# Altium schematic sheet BT WiFi Power Reset Control.SchDoc
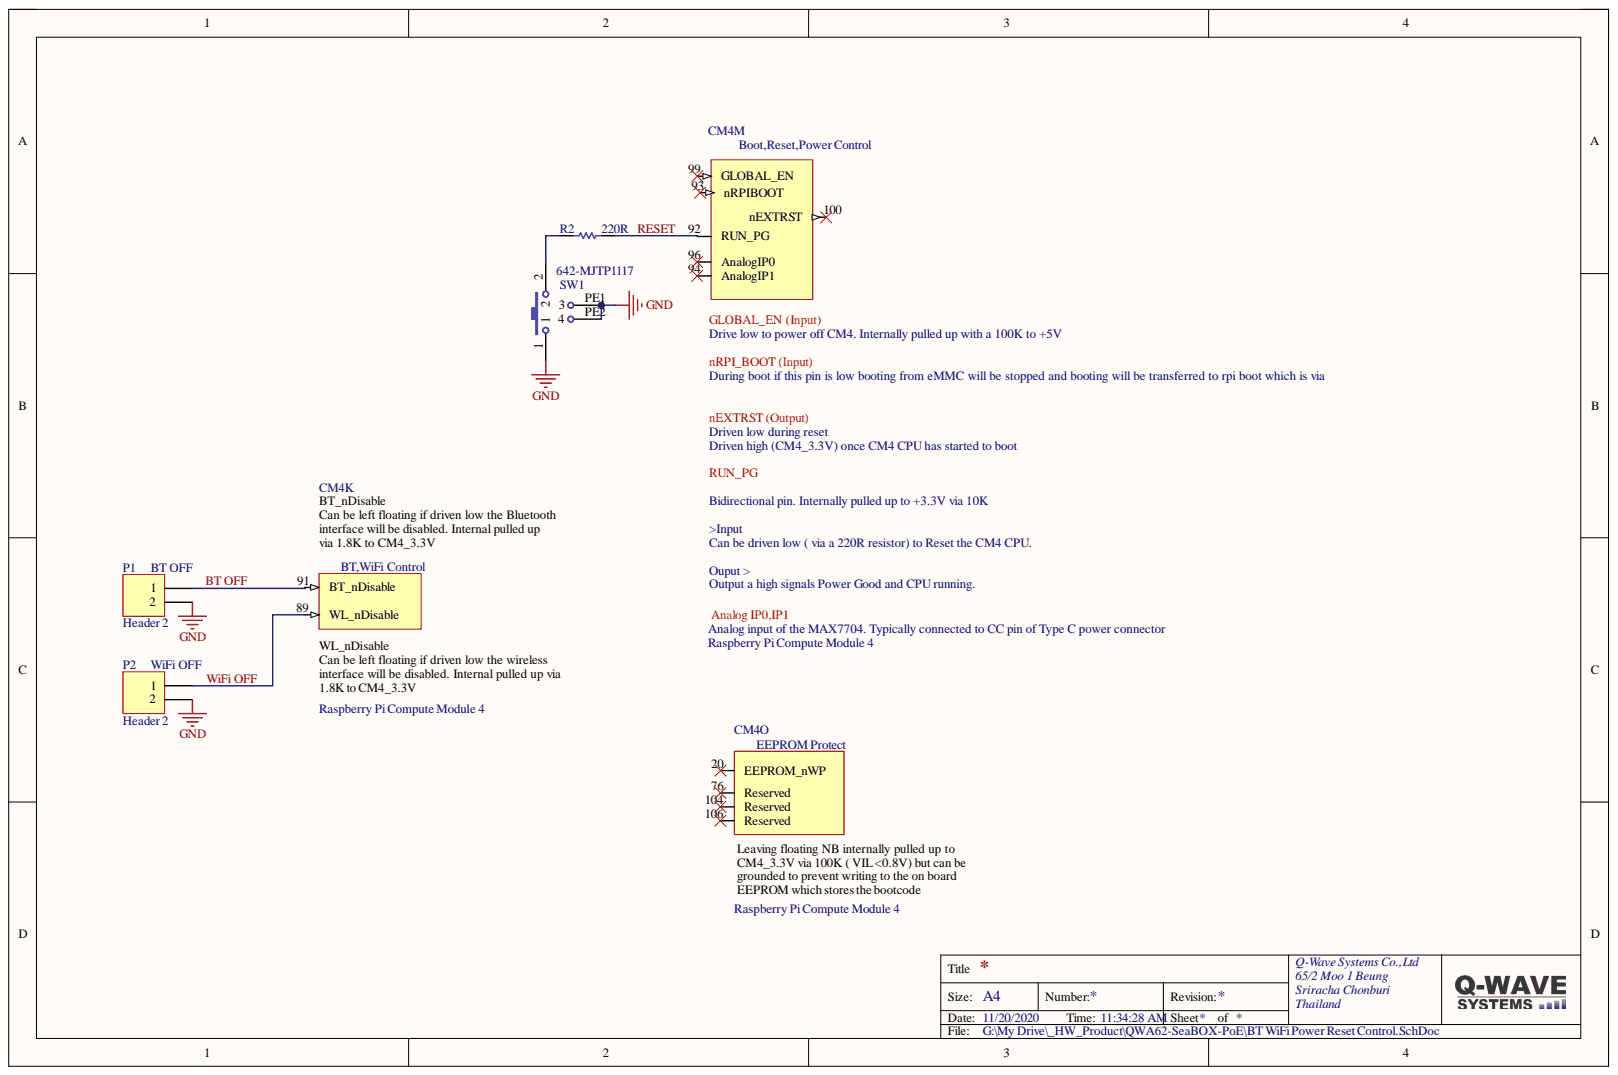
[diagram p0: BT WiFi Power Reset Control.SchDoc]

## Parts
CM4  Raspberry Pi Compute Module 4  [CM4-DF40HC(3.0)-100DS-0.4v_3mm_1.5mm]  (parts K,M,O)
P1  Header 2  [HDR1X2]
P2  Header 2  [HDR1X2]
R2  220R  [RES_0603]
SW1  MJTP1117  Supplier Part Number 1: 642-MJTP1117  [TSW DIP-3*6*4.3_V]

## Nets
GND: P1.2 P2.2 SW1.1 SW1.PE1 SW1.PE2
BT OFF: CM4.91(BT_nDisable) P1.1
RESET: CM4.92(RUN_PG) R2.1
WiFi OFF: CM4.89(WL_nDisable) P2.1
NetR2_2: R2.2 SW1.2

Not connected: CM4 20(EEPROM_nWP) 76(Reserved) 93(nRPIBOOT) 94(AnalogIP1) 96(AnalogIP0) 99(GLOBAL_EN) 100 104(Reserved) 106(Reserved)

## Library data of the parts
CM4 = CM4: Component Kind: Standard; Description: Raspberry Pi Compute Module 4; Library Name: CM4.SchLib
P1 P2 = Header 2: Header, 2-Pin; Component Kind: Standard; LatestRevisionDate: 17-Jul-2002; LatestRevisionNote: Re-released for DXP Platform.; Library Name: Miscellaneous Connectors.IntLib; Signal Integrity: Connector; Publisher: Altium Limited
R2 = Res Semi: 470R Resistor 0603; Component Kind: Standard; Library Name: SCH_Passive.SchLib; Signal Integrity: Res Semi; Simulation: RES
SW1 = SW-PB: TACT SWITCH Size 6mmx4.3 MJTP1117; Component Kind: Standard; Supplier 1: Mouser; Library Name: QwaveLib.IntLib
